# Altium schematic sheet P770010E05-Xx-SH-7_Microcontroller.SchDoc(P770010E05-Xx-SH-7_Microcontroller)
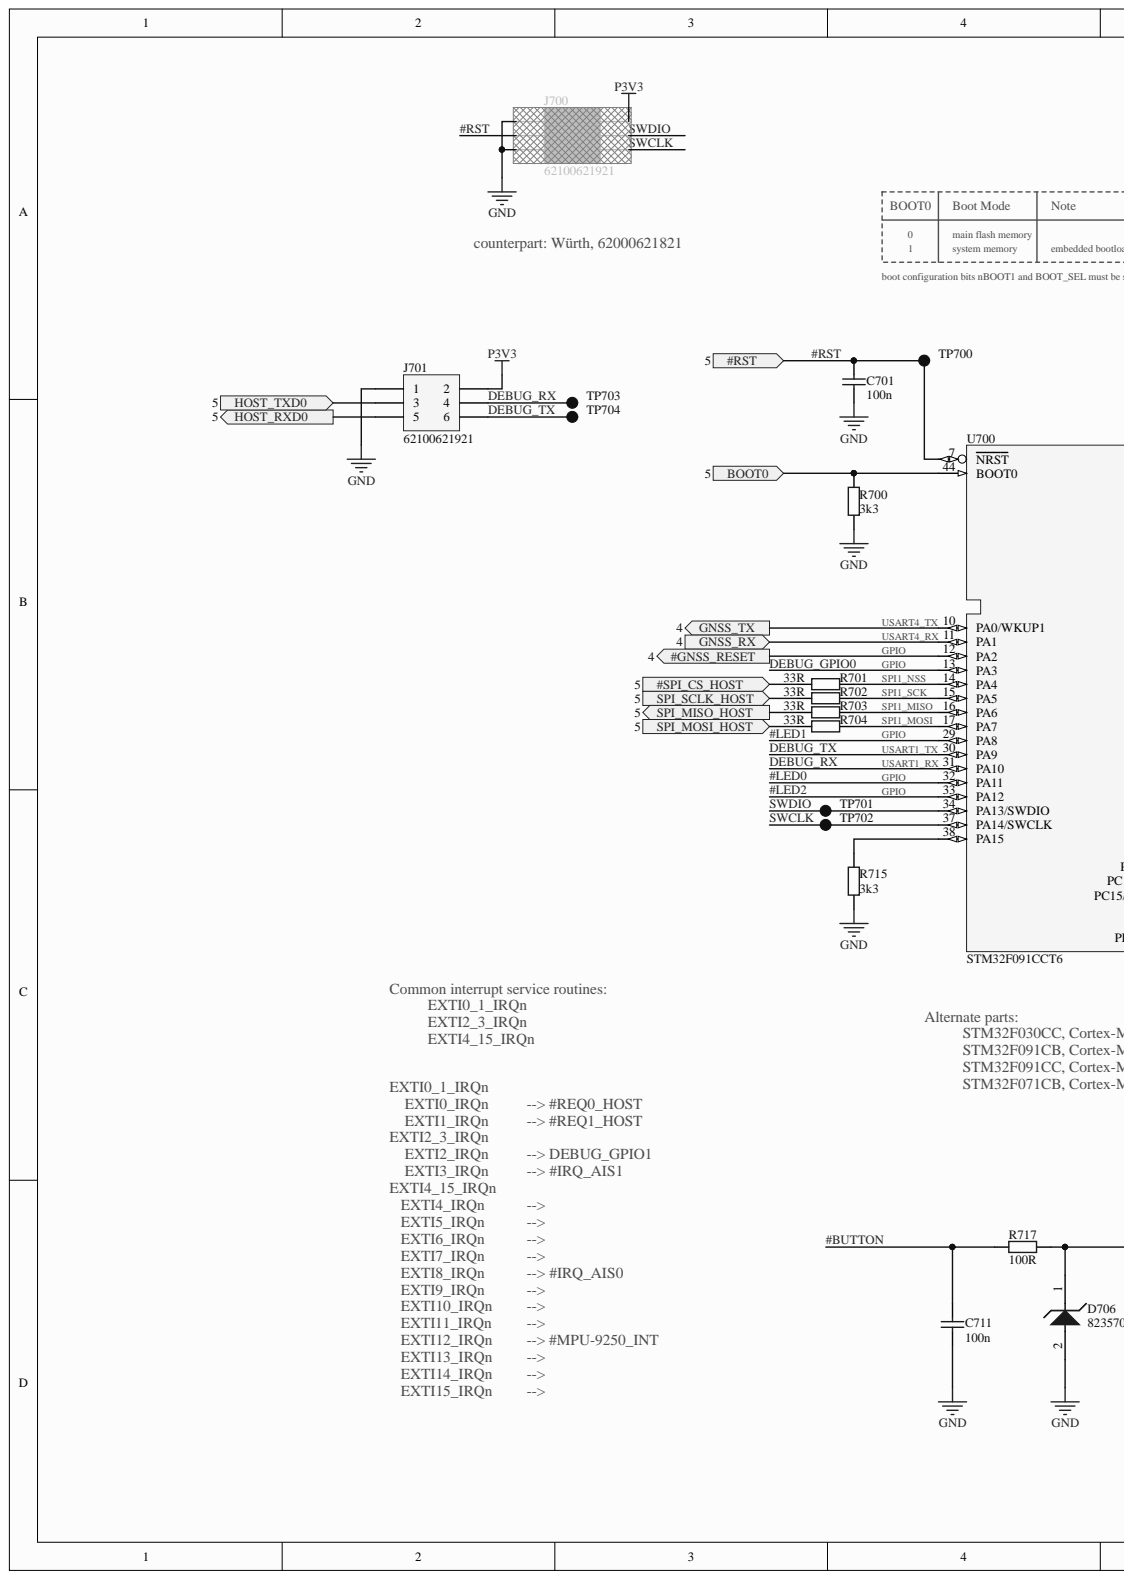
[diagram p0: P770010E05-Xx-SH-7_Microcontroller.SchDoc, left]
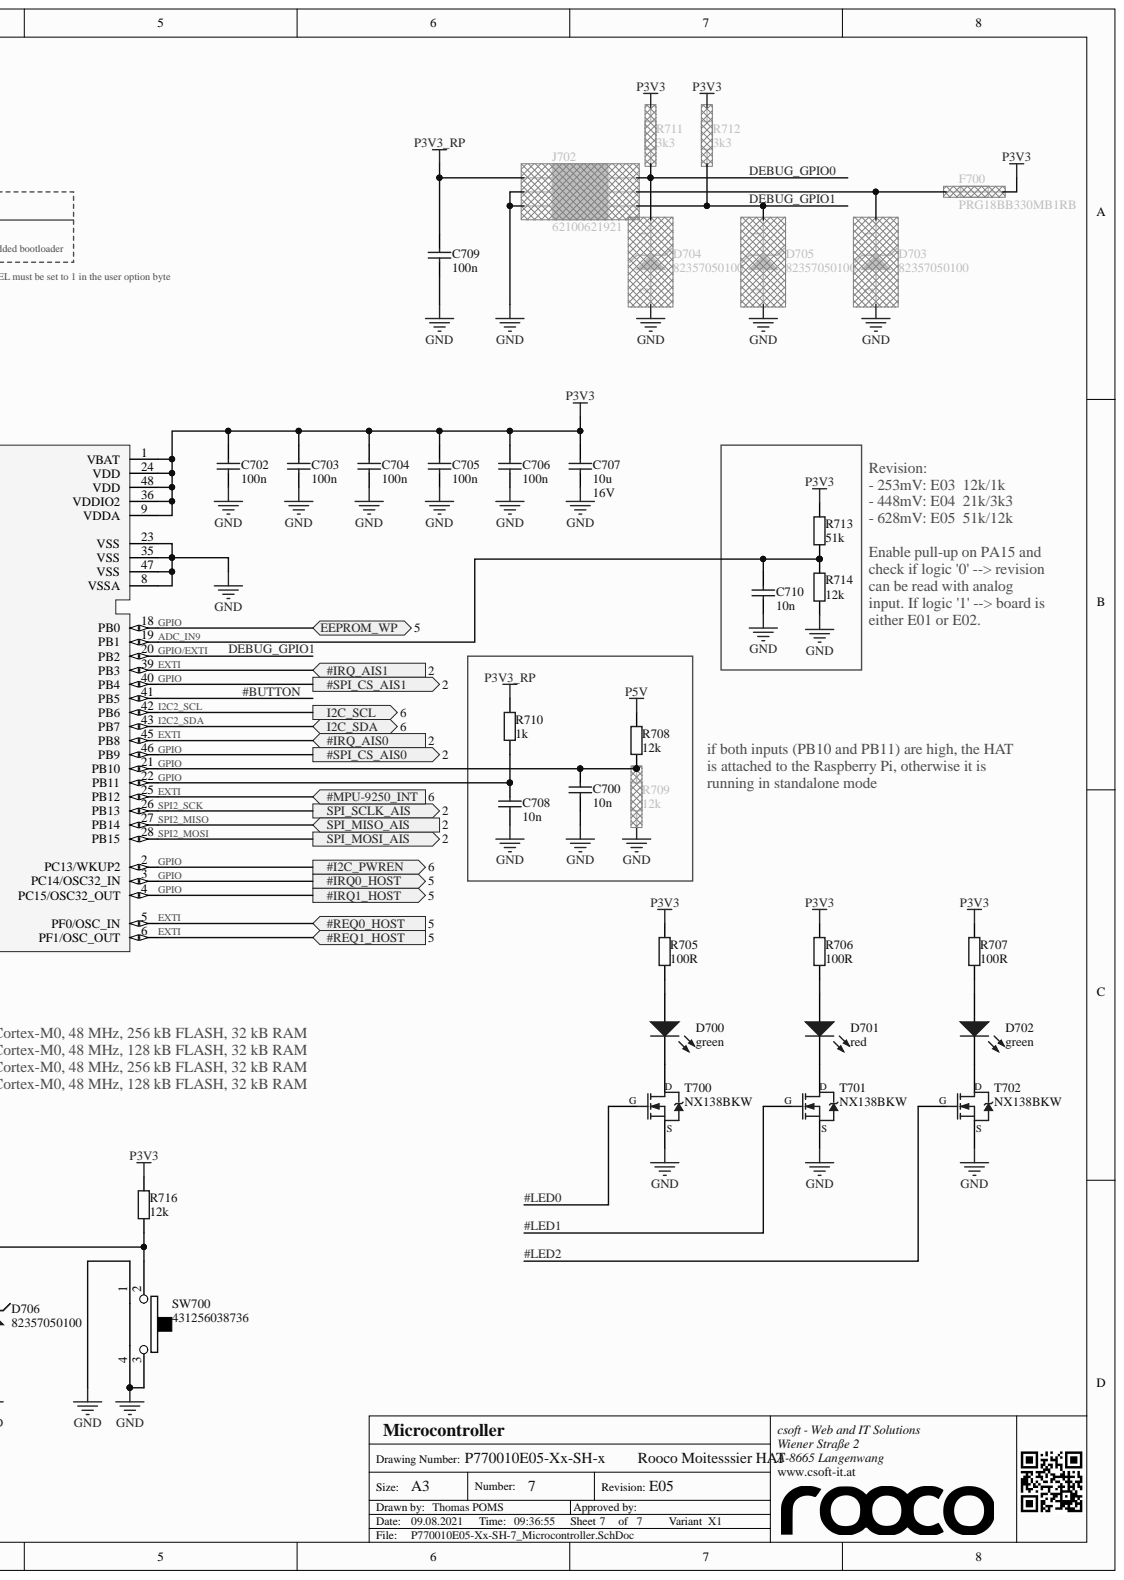
[diagram p1: P770010E05-Xx-SH-7_Microcontroller.SchDoc, right]

## Parts
C700  10n  [C0402]
C701  100n  [C0402]
C702  100n  [C0402]
C703  100n  [C0402]
C704  100n  [C0402]
C705  100n  [C0402]
C706  100n  [C0402]
C707  10u  VoltageTypical: 16V  [C0603]
C708  10n  [C0402]
C709  100n  [C0402]
C710  10n  [C0402]
C711  100n  [C0402]
D700  Color: green  [LTST-S270KGKT]  (hidden comment: LTST-S270KGKT)
D701  Color: red  [LTST-S270KRKT]  (hidden comment: LTST-S270KRKT)
D702  Color: green  [LTST-S270KGKT]  (hidden comment: LTST-S270KGKT)
D703  82357050100  [82357x]
D704  82357050100  [82357x]
D705  82357050100  [82357x]
D706  82357050100  [82357x]
F700  PRG18BB330MB1RB  [PRG18Bx_0603]
J700  62100621921  [62100621921]
J701  62100621921  [62100621921]
J702  62100621921  [62100621921]
R700  3k3  [R0402]
R701  33R  [R0402]
R702  33R  [R0402]
R703  33R  [R0402]
R704  33R  [R0402]
R705  100R  [R0402]
R706  100R  [R0402]
R707  100R  [R0402]
R708  12k  [R0402]
R709  12k  [R0402]
R710  1k  [R0402]
R711  3k3  [R0402]
R712  3k3  [R0402]
R713  51k  [R0402]
R714  12k  [R0402]
R715  3k3  [R0402]
R716  12k  [R0402]
R717  100R  [R0402]
SW700  431256038736  [431256038736]
T700  NX138BKW  [NX138BKW_SC-70-3]
T701  NX138BKW  [NX138BKW_SC-70-3]
T702  NX138BKW  [NX138BKW_SC-70-3]
TP700  testpoint  [TP_1.27x1.27]  (value not drawn)  (no BOM)
TP701  testpoint  [TP_1.27x1.27]  (value not drawn)  (no BOM)
TP702  testpoint  [TP_1.27x1.27]  (value not drawn)  (no BOM)
TP703  testpoint  [TP_1.27x1.27]  (value not drawn)  (no BOM)
TP704  testpoint  [TP_1.27x1.27]  (value not drawn)  (no BOM)
U700  STM32F091CCT6  [STM32F091CxTx_LQFP-48]

## Ports
#GNSS_RESET, #I2C_PWREN, #IRQ0_HOST, #IRQ1_HOST, #IRQ_AIS0, #IRQ_AIS1, #MPU-9250_INT, #REQ0_HOST, #REQ1_HOST, #RST, #SPI_CS_AIS0, #SPI_CS_AIS1, #SPI_CS_HOST, BOOT0, EEPROM_WP, GNSS_RX, GNSS_TX, HOST_RXD0, HOST_TXD0, I2C_SCL, I2C_SDA, SPI_MISO_AIS, SPI_MISO_HOST, SPI_MOSI_AIS, SPI_MOSI_HOST, SPI_SCLK_AIS, SPI_SCLK_HOST

## Nets
GND: C700.1 C701.1 C702.1 C703.1 C704.1 C705.1 C706.1 C707.1 C708.1 C709.1 C710.1 C711.1 D703.2 D704.2 D705.2 D706.2 J700.1 J700.5 J701.1 J702.3 J702.5 R700.1 R709.1 R714.2 R715.1 SW700.1 SW700.3 SW700.4 T700.S T701.S T702.S U700.8(VSSA) U700.23(VSS) U700.35(VSS) U700.47(VSS)
P3V3: C702.2 C703.2 C704.2 C705.2 C706.2 C707.2 F700.2 J700.2 J701.2 R705.2 R706.2 R707.2 R711.2 R712.2 R713.2 R716.2 U700.1(VBAT) U700.9(VDDA) U700.24(VDD) U700.36(VDDIO2) U700.48(VDD)
P3V3_RP: C709.2 J702.1 R710.1
P5V: R708.2
#BUTTON: C711.2 R717.2 U700.41(PB5)
#GNSS_RESET: U700.12(PA2) ; port #GNSS_RESET
#I2C_PWREN: U700.2(PC13/WKUP2) ; port #I2C_PWREN
#IRQ0_HOST: U700.3(PC14/OSC32_IN) ; port #IRQ0_HOST
#IRQ1_HOST: U700.4(PC15/OSC32_OUT) ; port #IRQ1_HOST
#IRQ_AIS0: U700.45(PB8) ; port #IRQ_AIS0
#IRQ_AIS1: U700.39(PB3) ; port #IRQ_AIS1
#LED0: T700.G U700.32(PA11)
#LED1: T701.G U700.29(PA8)
#LED2: T702.G U700.33(PA12)
#MPU-9250_INT: U700.25(PB12) ; port #MPU-9250_INT
#REQ0_HOST: U700.5(PF0/OSC_IN) ; port #REQ0_HOST
#REQ1_HOST: U700.6(PF1/OSC_OUT) ; port #REQ1_HOST
#RST: C701.2 J700.3 TP700.1 U700.7(NRST) ; port #RST
#SPI_CS_AIS0: U700.46(PB9) ; port #SPI_CS_AIS0
#SPI_CS_AIS1: U700.40(PB4) ; port #SPI_CS_AIS1
#SPI_CS_HOST: R701.1 ; port #SPI_CS_HOST
BOOT0: R700.2 U700.44(BOOT0) ; port BOOT0
DEBUG_GPIO0: D704.1 J702.2 R711.1 U700.13(PA3)
DEBUG_GPIO1: D705.1 J702.6 R712.1 U700.20(PB2)
DEBUG_RX: J701.4 TP703.1 U700.31(PA10)
DEBUG_TX: J701.6 TP704.1 U700.30(PA9)
EEPROM_WP: U700.18(PB0) ; port EEPROM_WP
GNSS_RX: U700.11(PA1) ; port GNSS_RX
GNSS_TX: U700.10(PA0/WKUP1) ; port GNSS_TX
HOST_RXD0: J701.5 ; port HOST_RXD0
HOST_TXD0: J701.3 ; port HOST_TXD0
I2C_SCL: U700.42(PB6) ; port I2C_SCL
I2C_SDA: U700.43(PB7) ; port I2C_SDA
SPI_MISO_AIS: U700.27(PB14) ; port SPI_MISO_AIS
SPI_MISO_HOST: R703.1 ; port SPI_MISO_HOST
SPI_MOSI_AIS: U700.28(PB15) ; port SPI_MOSI_AIS
SPI_MOSI_HOST: R704.1 ; port SPI_MOSI_HOST
SPI_SCLK_AIS: U700.26(PB13) ; port SPI_SCLK_AIS
SPI_SCLK_HOST: R702.1 ; port SPI_SCLK_HOST
SWCLK: J700.6 TP702.1 U700.37(PA14/SWCLK)
SWDIO: J700.4 TP701.1 U700.34(PA13/SWDIO)
NetC700_2: C700.2 R708.1 R709.2 U700.21(PB10)
NetC708_2: C708.2 R710.2 U700.22(PB11)
NetC710_2: C710.2 R713.1 R714.1 U700.19(PB1)
NetD700_1: D700.1 R705.1
NetD700_2: D700.2 T700.D
NetD701_1: D701.1 R706.1
NetD701_2: D701.2 T701.D
NetD702_1: D702.1 R707.1
NetD702_2: D702.2 T702.D
NetD703_1: D703.1 F700.1 J702.4
NetD706_1: D706.1 R716.1 R717.1 SW700.2
NetR701_2: R701.2 U700.14(PA4)
NetR702_2: R702.2 U700.15(PA5)
NetR703_2: R703.2 U700.16(PA6)
NetR704_2: R704.2 U700.17(PA7)
NetR715_2: R715.2 U700.38(PA15)

## Library data of the parts
C700 C708 C710 = GCM155R71H103KA55D: ceramic chip capacitor, 10nF, 50V, X7R, 0402; Manufacturer: Murata; ID: 13; Obsolete: False; Published by: FT-TEC; RevisionHistory: [1.0]; ToleranceValue: +/-10%; Component Kind: Standard; ComponentLink1Description: Datasheet; ComponentLink1URL: http://search.murata.co.jp/Ceramy/image/img/PDF/ENG/GCM155R71H103KA55.pdf; ComponentType: Standard; Database Table Name: Capacitor; Dielectric: X7R; Library Name: CompanyDatabase.DbLib; Package: 0402; Part Number: GCM155R71H103KA55D; Pin Count: 2; Published: 26.02.2012; Revision: 1.0; TemperatureMax: 125°C; TemperatureMin: -55°C; Value: 10n; VoltageTypical: 50V; Checked by: Thomas POMS; Drawn by: Thomas POMS
C701-C706 C709 C711 = C1005X7R1H104K050BB: ceramic chip capacitor, 100n, 50V, X7R, 0402; Manufacturer: TDK; ID: 1; Obsolete: False; Published by: FT-TEC; RevisionHistory: [1.0]; ToleranceValue: +/-10%; Component Kind: Standard; ComponentLink1Description: Datasheet; ComponentLink1URL: http://www.tdk.com/pdf/TDKMLCCCapRange.pdf; ComponentType: Standard; Database Table Name: Capacitor; Dielectric: X7R; Library Name: CompanyDatabase.DbLib; Package: 0402; Part Number: C1005X7R1H104K050BB; Pin Count: 2; Published: 16.02.2012; Revision: 1.0; TemperatureMax: 125°C; TemperatureMin: -55°C; Value: 100n; VoltageTypical: 50V; Checked by: Thomas POMS; Drawn by: Thomas POMS
C707 = GRM188R61C106KAALJ: ceramic chip capacitor, 10uF, 16V, X5R, 0603; Manufacturer: Murata; ID: 68; Obsolete: False; Published by: FT-TEC; RevisionHistory: [1.0] Creation; ToleranceValue: +/-10%; Component Kind: Standard; ComponentLink1Description: Datasheet; ComponentLink1URL: http://search.murata.co.jp/Ceramy/image/img/A01X/partnumbering_e_01.pdf; ComponentType: Standard; Database Table Name: Capacitor; Dielectric: X5R; Library Name: CompanyDatabase.DbLib; Package: 0603; Part Number: GRM188R61C106KAALJ; Pin Count: 2; Published: 03.09.2014; Revision: 1.0; TemperatureMax: 85°C; TemperatureMin: -55°C; Value: 10u; Checked by: Thomas POMS; Drawn by: Thomas POMS
D700 D702 = LTST-S270KGKT: LED, green, 45mcd; Manufacturer: Lite-On; CurrentConsumption: 20mA; ID: 13; Obsolete: False; Published by: FT-TEC; RevisionHistory: [1.0] Creation; VoltageMax: 2.4V; VoltageTypical: 2.0V; Component Kind: Standard; ComponentLink1Description: Datasheet; ComponentLink1URL: http://optoelectronics.liteon.com/upload/download/DS22-2000-226/S_110_LTST-S270KGKT.pdf; ComponentType: Standard; Database Table Name: LED; Library Name: CompanyDatabase.DbLib; Part Number: LTST-S270KGKT; Pin Count: 2; Published: 15.02.2017; Revision: 1.0; TemperatureMax: 80°C; TemperatureMin: -30°C; Value: LTST-S270KGKT; Checked by: Thomas POMS; Drawn by: Thomas POMS
D701 = LTST-S270KRKT: LED, red, 54mcd; Manufacturer: Lite-On; CurrentConsumption: 20mA; ID: 14; Obsolete: False; Published by: FT-TEC; RevisionHistory: [1.0] Creation; VoltageMax: 2.4V; VoltageTypical: 2.0V; Component Kind: Standard; ComponentLink1Description: Datasheet; ComponentLink1URL: http://optoelectronics.liteon.com/upload/download/DS22-2000-210/S_110_LTST-S270KRKT.pdf; ComponentType: Standard; Database Table Name: LED; Library Name: CompanyDatabase.DbLib; Part Number: LTST-S270KRKT; Pin Count: 2; Published: 26.02.2017; Revision: 1.0; TemperatureMax: 80°C; TemperatureMin: -30°C; Value: LTST-S270KRKT; Checked by: Thomas POMS; Drawn by: Thomas POMS
D703-D706 = 82357050100: ESD suppressor, 5VDC, 60Vclamp, 10pF; Manufacturer: Würth; Database Table Name: Diode; ID: 27; Obsolete: False; Published by: FT-TEC; RevisionHistory: [1.0] Creation; Component Kind: Standard; ComponentLink1Description: Datasheet; ComponentLink1URL: http://katalog.we-online.de/pbs/datasheet/82357050100.pdf; ComponentType: Standard; Library Name: CompanyDatabase.DbLib; Package: 0402; Part Number: 82357050100; Pin Count: 2; Published: 30.07.2015; Revision: 1.0; TemperatureMax: 85°C; TemperatureMin: -40°C; Value: 82357050100; Checked by: Thomas POMS; Drawn by: Thomas POMS
F700 = PRG18BB330MB1RB: fuse, 36 mA, 0603, 33 RDC, 24 Vmax; Manufacturer: Murata; CurrentRating: 36mA; ID: 11; Obsolete: False; Published by: FT-TEC; RevisionHistory: [1.0] Creation; VoltageMax: 24VDC; Component Kind: Standard; ComponentLink1Description: Datasheet; ComponentLink1URL: http://www.murata.com/products/catalog/pdf/r90e.pdf; ComponentType: Standard; Database Table Name: Fuse; Library Name: CompanyDatabase.DbLib; Package: 0603; Part Number: PRG18BB330MB1RB; Pin Count: 2; Published: 21.02.2018; Revision: 1.0; TemperatureMax: 90°C; Value: PRG18BB330MB1RB; Checked by: Thomas POMS; Drawn by: Thomas POMS
J700-J702 = 62100621921: header, 2mm, 2x3pin, vertical; Manufacturer: Würth; CurrentRating: 2.0A; ID: 23; Obsolete: False; Published by: FT-TEC; RevisionHistory: [1.0] Creation; Component Kind: Standard; ComponentLink1Description: Datasheet; ComponentLink1URL: http://katalog.we-online.de/em/datasheet/6210xx21921.pdf; ComponentType: Standard; Database Table Name: Header; Library Name: CompanyDatabase.DbLib; Part Number: 62100621921; PinClearance: 2mm; Pin Count: 6; Published: 23.12.2015; Revision: 1.0; TemperatureMax: 125°C; TemperatureMin: -40°C; Value: 62100621921; Checked by: Thomas POMS; Drawn by: Thomas POMS
R700 R711 R712 R715 = CRCW04023K30FKED: thick film resistor, 3.3k, 1%, 100ppm,  0402; Manufacturer: Vishay; ID: 20; Obsolete: False; PowerRating: 63mW; Published by: FT-TEC; RevisionHistory: [1.0] Creation; VoltageRating: 50V; Component Kind: Standard; ComponentLink1Description: Datasheet; ComponentLink1URL: http://www.vishay.com/docs/20035/dcrcwe3.pdf; ComponentType: Standard; Database Table Name: Resistor; Library Name: CompanyDatabase.DbLib; Package: 0402; Part Number: CRCW04023K30FKED; Pin Count: 2; Published: 27.02.2012; Revision: 1.0; TemperatureCoefficient: 100ppm; TemperatureMax: 155°C; TemperatureMin: -55°C; ToleranceValue: 1%; Value: 3k3; Checked by: Thomas POMS; Drawn by: Thomas Poms
R701-R704 = CRCW040233R0FKED: thick film resistor, 33R, 1% , 100ppm, 0402; Manufacturer: Vishay; ID: 3; Obsolete: False; PowerRating: 63mW; Published by: FT-TEC; RevisionHistory: [1.0] Creation; VoltageRating: 50V; Component Kind: Standard; ComponentLink1Description: Datasheet; ComponentLink1URL: http://www.vishay.com/docs/20035/dcrcwe3.pdf; ComponentType: Standard; Database Table Name: Resistor; Library Name: CompanyDatabase.DbLib; Package: 0402; Part Number: CRCW040233R0FKED; Pin Count: 2; Published: 16.02.2012; Revision: 1.0; TemperatureCoefficient: 100ppm; TemperatureMax: 155°C; TemperatureMin: -55°C; ToleranceValue: 1%; Value: 33R; Checked by: Thomas POMS; Drawn by: Thomas Poms
R705-R707 R717 = CRCW0402100RFKED: thick film resistor, 100R, 1%, 100ppm,  0402; Manufacturer: Vishay; ID: 18; Obsolete: False; PowerRating: 63mW; Published by: FT-TEC; RevisionHistory: [1.0] Creation; VoltageRating: 50V; Component Kind: Standard; ComponentLink1Description: Datasheet; ComponentLink1URL: http://www.vishay.com/docs/20035/dcrcwe3.pdf; ComponentType: Standard; Database Table Name: Resistor; Library Name: CompanyDatabase.DbLib; Package: 0402; Part Number: CRCW0402100RFKED; Pin Count: 2; Published: 27.02.2012; Revision: 1.0; TemperatureCoefficient: 100ppm; TemperatureMax: 155°C; TemperatureMin: -55°C; ToleranceValue: 1%; Value: 100R; Checked by: Thomas POMS; Drawn by: Thomas Poms
R708 R709 R714 R716 = CRCW040212K0FKED: thick film resistor, 12k, 1%, 100ppm, 0402, 63mW; Manufacturer: Vishay; ID: 103; Obsolete: False; Published by: FT-TEC; RevisionHistory: [1.0] Creation; VoltageRating: 50V; Component Kind: Standard; ComponentLink1Description: Datasheet; ComponentLink1URL: http://www.vishay.com/docs/20035/dcrcwe3.pdf; ComponentType: Standard; Database Table Name: Resistor; Library Name: CompanyDatabase.DbLib; Package: 0402; Part Number: CRCW040212K0FKED; Pin Count: 2; PowerRating: 63mW; Published: 04.04.2017; Revision: 1.0; TemperatureCoefficient: 100ppm; TemperatureMax: 155°C; TemperatureMin: -55°C; ToleranceValue: 1%; Value: 12k; Checked by: Thomas POMS; Drawn by: Thomas POMS
R710 = CRCW04021K00FKED: thick film resistor, 1k, 1%, 100ppm, 0402; Manufacturer: Vishay; ID: 4; Obsolete: False; PowerRating: 63mW; Published by: FT-TEC; RevisionHistory: [1.0] Creation; VoltageRating: 50V; Component Kind: Standard; ComponentLink1Description: Datasheet; ComponentLink1URL: http://www.vishay.com/docs/20035/dcrcwe3.pdf; ComponentType: Standard; Database Table Name: Resistor; Library Name: CompanyDatabase.DbLib; Package: 0402; Part Number: CRCW04021K00FKED; Pin Count: 2; Published: 16.02.2012; Revision: 1.0; TemperatureCoefficient: 100ppm; TemperatureMax: 155°C; TemperatureMin: -55°C; ToleranceValue: 1%; Value: 1k; Checked by: Thomas POMS; Drawn by: Thomas Poms
R713 = CRCW040251K0FKED: thick film resistor, 51k, 1%, 100ppm,  0402; Manufacturer: Vishay; ID: 40; Obsolete: False; PowerRating: 63mW; Published by: FT-TEC; RevisionHistory: [1.0] Creation; VoltageRating: 50V; Component Kind: Standard; ComponentLink1Description: Datasheet; ComponentLink1URL: http://www.vishay.com/docs/20035/dcrcwe3.pdf#http://www.vishay.com/docs/20035/dcrcwe3.pdf; ComponentType: Standard; Database Table Name: Resistor; Library Name: CompanyDatabase.DbLib; Package: 0402; Part Number: CRCW040251K0FKED; Pin Count: 2; Published: 01.07.2013; Revision: 1.0; TemperatureCoefficient: 100ppm; TemperatureMax: 155°C; TemperatureMin: -55°C; ToleranceValue: 1%; Value: 51k; Checked by: Thomas POMS; Drawn by: Thomas POMS
SW700 = 431256038736: tactile switch, THT, right angle, 6x6mm; Manufacturer: Würth; CurrentRating: 50mA; Database Table Name: Button; ID: 6; Obsolete: False; Published by: poms-engineering; RevisionHistory: [1.0] Creation; VoltageRating: 12VDC; Component Kind: Standard; ComponentLink1Description: Datasheet; ComponentLink1URL: https://katalog.we-online.com/em/datasheet/431256038736.pdf; ComponentType: Standard; Library Name: CompanyDatabase.DbLib; Part Number: 431256038736; Pin Count: 4; Published: 24.10.2019; Revision: 1.0; TemperatureMax: 85°C; TemperatureMin: -40°C; Value: 431256038736; Checked by: Thomas POMS; Drawn by: Thomas POMS
T700-T702 = NX138BKW: N-Channel Trench MOSFET, 60V, 210 mA; Manufacturer: NEXPERIA; DrainCurrent: 210mA; ID: 15; Obsolete: False; Published by: FT-TEC; RDSon: 3.5R; RevisionHistory: [1.0] Creation; RJA: 390°C/W; Type: NMOS; VGS: +/-20V; VGS(th)Max: 1.5V; VGS(th)Min: 0.5V; VGS(th)Typical: 1.0V; Component Kind: Standard; ComponentLink1Description: Datasheet; ComponentLink1URL: https://assets.nexperia.com/documents/data-sheet/NX138BKW.pdf; ComponentType: Standard; Database Table Name: MOSFET; Library Name: CompanyDatabase.DbLib; Package: SOT-323; Part Number: NX138BKW; Pin Count: 3; Published: 30.10.2017; Revision: 1.0; TemperatureMax: 150°C; TemperatureMin: -55°C; Value: NX138BKW; VDSmax: 60V; Checked by: Thomas POMS; Drawn by: Thomas POMS
TP700-TP704 = TP_1.27x1.27: Testpoint 1.27mm x 1.27mm; Manufacturer: none; ID: 1; Obsolete: False; Published by: FT-TEC; RevisionHistory: [1.0] Creation; ComponentType: Standard; Database Table Name: Testpoint; Library Name: CompanyDatabase.DbLib; Part Number: TP_1.27x1.27; Revision: 1.0; Value: TP_1.27x1.27; Checked by: Thomas POMS; Drawn by: Thomas POMS
U700 = STM32F091CCT6: ARM Cortex-M0 32bit MCU, 256 kByte Flash, 32 kByte RAM, 48 MHz; Manufacturer: STMicroelectronics; Database Table Name: Microcontroller; ID: 33; Obsolete: False; Published by: poms-engineering; RevisionHistory: [1.0] Creation; VoltageMax: 3.6V; VoltageMin: 2.0V; VoltageTypical: 3.3V; Component Kind: Standard; ComponentLink1Description: Documentation; ComponentLink1URL: http://www.st.com/content/st_com/en/products/microcontrollers/stm32-32-bit-arm-cortex-mcus/stm32-mainstream-mcus/stm32f0-series/stm32f0x1/stm32f091cc.html; ComponentType: Standard; Library Name: CompanyDatabase.DbLib; Package: LQFP-48; Part Number: STM32F091CCT6; Pin Count: 48; Published: 20.03.2018; Revision: 1.0; TemperatureMax: 85°C; TemperatureMin: -40°C; Value: STM32F091CCT6; Checked by: Thomas POMS; Drawn by: Thomas POMS
